# Altium schematic sheet EchoFX.SchDoc
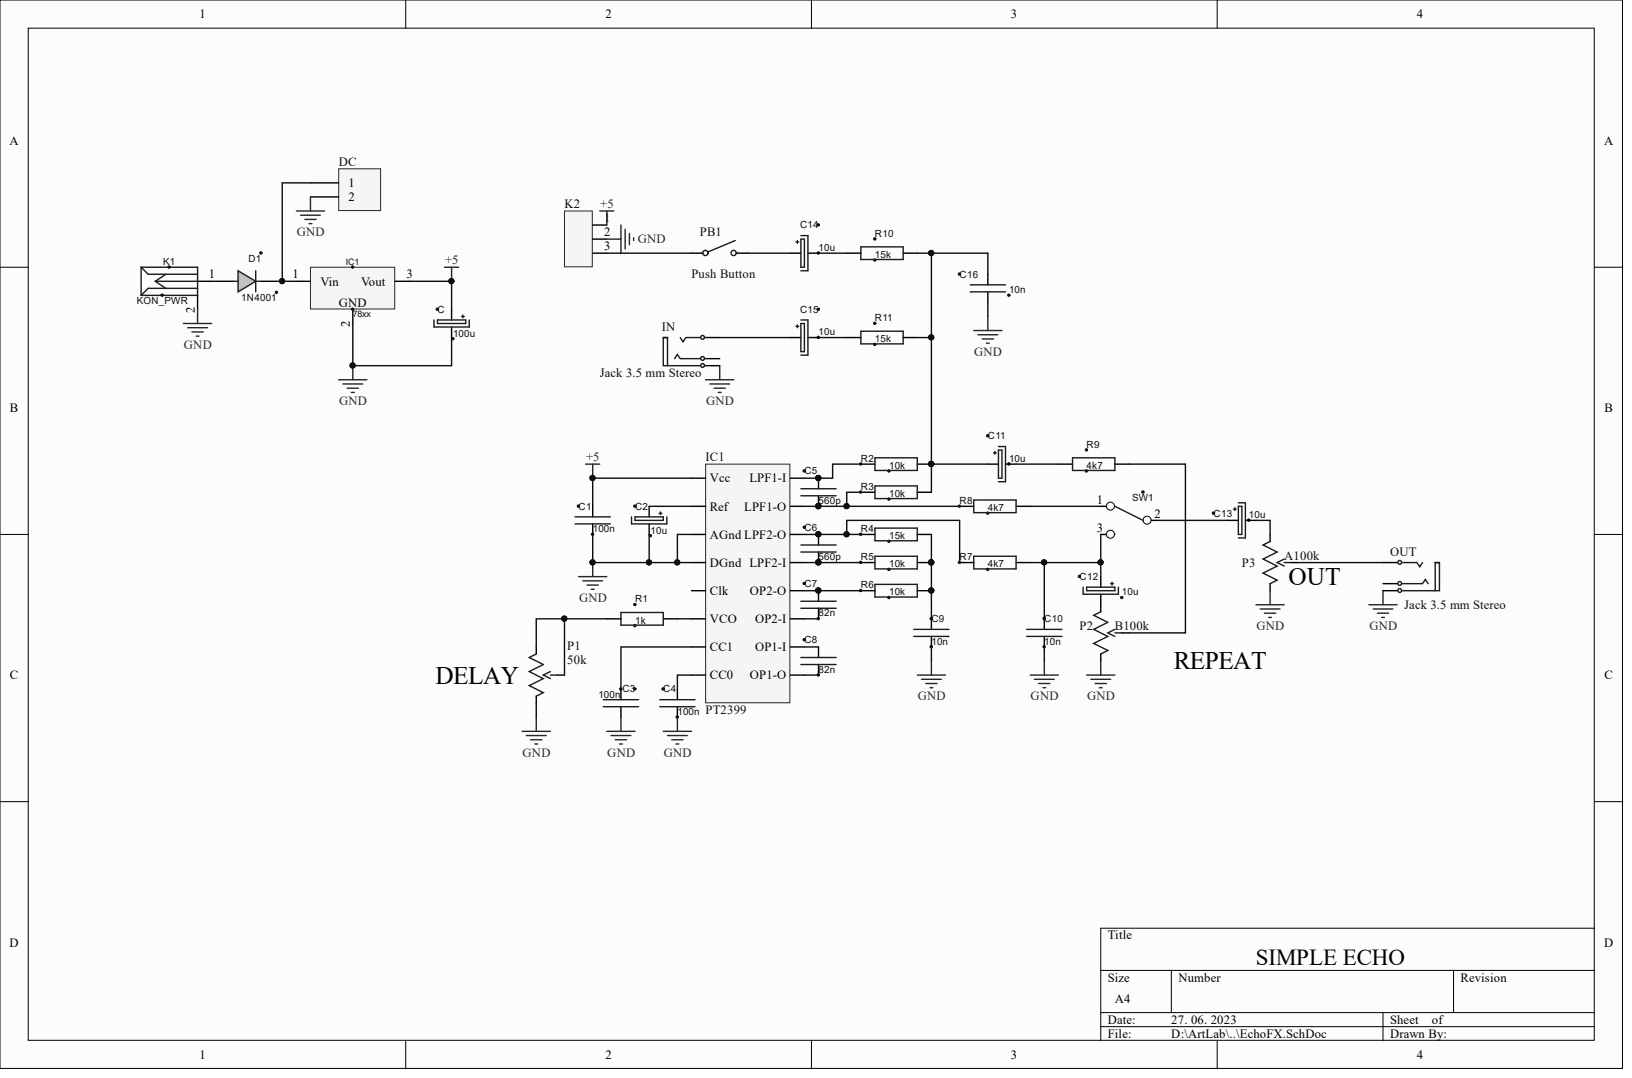
[diagram p0: EchoFX.SchDoc]

## Parts
C  100u  [C AlElko R2.54 FI5]
C1  100n  [C Square R5.08 (200mil)]
C2  10u  [C AlElko R2.54 FI5]
C3  100n  [C Square R5.08 (200mil)]
C4  100n  [C Square R5.08 (200mil)]
C5  560p  [C Square R5.08 (200mil)]
C6  560p  [C Square R5.08 (200mil)]
C7  82n  [C Square R5.08 (200mil)]
C8  82n  [C Square R5.08 (200mil)]
C9  10n  [C Square R5.08 (200mil)]
C10  10n  [C Square R5.08 (200mil)]
C11  10u  [C AlElko R2.54 FI5]
C12  10u  [C AlElko R2.54 FI5]
C13  10u  [C AlElko R2.54 FI5]
C14  10u  [C AlElko R2.54 FI5]
C15  10u  [C AlElko R2.54 FI5]
C16  10n  [C Square R5.08 (200mil)]
D1  1N4001  [DO 41 (400MILS) larger pads]
DC  Header 2H  [HDR1X2H]  (value not drawn)
IC1  78xx  [TO-92]
IC1  PT2399  [DIP16]
IN  Jack 3.5 mm Stereo  [FC68131]
K1  KON_PWR  [_KON_PWR_KON_PWR(Primary)]
K2  H1x3  [H1x3]  (value not drawn)
OUT  Jack 3.5 mm Stereo  [FC68131]
P1  50k  [PDB181- P]
P2  B100k  [PDB181- P]
P3  A100k  [PDB181- P]
PB1  Push Button  [D6R SPST]
R1  1k  [R300]
R2  10k  [R300]
R3  10k  [R300]
R4  15k  [R300]
R5  10k  [R300]
R6  10k  [R300]
R7  4k7  [R300]
R8  4k7  [R300]
R9  4k7  [R300]
R10  15k  [R300]
R11  15k  [R300]
SW1  OS102011MA1QN1  [OS102011-3POLNI SLIDE]  (value not drawn)

## Nets
+5: C.1 C1.2 IC1.1 IC1.3 K2.1
GND: C.2 C1.1 C2.2 C3.2 C4.1 C9.1 C10.1 C16.1 DC.2 IC1.2 IC1.3 IC1.4(DGnd) IN.1 K1.2 K2.2 OUT.1 P1.1 P2.1 P3.1
NetC2_1: C2.1 IC1.2
NetC3_1: C3.1 IC1.7(CC1)
NetC4_2: C4.2 IC1.8(CC0)
NetC5_1: C5.1 IC1.15(LPF1-O) R3.2 R8.1
NetC5_2: C5.2 IC1.16(LPF1-I) R2.2
NetC6_1: C6.1 IC1.13(LPF2-I) R5.1
NetC6_2: C6.2 IC1.14(LPF2-O) R4.2 R7.1
NetC7_1: C7.1 IC1.11(OP2-I)
NetC7_2: C7.2 IC1.12(OP2-O) R6.1
NetC8_1: C8.1 IC1.9(OP1-O)
NetC8_2: C8.2 IC1.10(OP1-I)
NetC9_2: C9.2 R4.1 R5.2 R6.2
NetC10_2: C10.2 C12.1 R7.2 SW1.3
NetC11_1: C11.1 C16.2 R2.1 R3.1 R10.2 R11.2
NetC11_2: C11.2 R9.2
NetC12_2: C12.2 P2.3
NetC13_1: C13.1 SW1.2
NetC13_2: C13.2 P3.3
NetC14_1: C14.1 PB1.2
NetC14_2: C14.2 R10.1
NetC15_1: C15.1 IN.5
NetC15_2: C15.2 R11.1
NetD1_A: D1.A K1.1
NetD1_K: D1.K DC.1 IC1.1
NetIC1_6: IC1.6(VCO) R1.2
NetK2_3: K2.3 PB1.1
NetOUT_5: OUT.5 P3.2
NetP1_2: P1.2 P1.3 R1.1
NetP2_2: P2.2 R9.1
NetR8_2: R8.2 SW1.1

Not connected: IC1 5(Clk); IN 2; OUT 2

## Library data of the parts
C C2 C11-C15 = C+: Capacitor Polarized; Component Kind: Standard; Library Name: General.IntLib
  C: Value: 100u
  C2 C11-C15: Value: 10u
C1 C3-C10 C16 = C: Capacitor; Component Kind: Standard; Library Name: General.IntLib
  C1 C3 C4: Value: 100n
  C5 C6: Value: 560p
  C7 C8: Value: 82n
  C9 C10 C16: Value: 10n
DC = Header 2H: Header, 2-Pin, Right Angle; Component Kind: Standard; LatestRevisionDate: 17-Jul-2002; LatestRevisionNote: Re-released for DXP Platform.; Library Name: Miscellaneous Connectors.IntLib; Signal Integrity: Connector; Publisher: Altium Limited
IC1 = 78xx: 3-point linear voltage regulator series 78xx; Component Kind: Standard; Library Name: ICgeneral.IntLib
IC1 = PT2399 Echo-Delay: Component Kind: Standard; Description: PT2399 Echo-Delay; Library Name: Eurorack.IntLib
IN OUT = Stereo Jack 3.5 mm 3-pin: Jack 3.5 mm PCB mount 3-pin Stereo; Component Kind: Standard; ComponentLink1Description: Datasheet; ComponentLink1URL: https://si.farnell.com/cliff-electronic-components/fc68131/stereo-jack-3-5mm-3pos-pcb/dp/2518188; ComponentLink1URL: http://si.farnell.com/webapp/wcs/stores/servlet/ProductDisplay?catalogId=10001&langId=386&urlRequestType=Base&partNumber=1267400&storeId=10175; Library Name: Eurorack.IntLib
K2 = H1x3: Header 1x3 (male); Component Kind: Standard; Library Name: Kon_Tipk.IntLib
P1-P3 = Potentiometer Rotary: Component Kind: Standard; ComponentLink1description: datasheet; ComponentLink1URL: https://www.bourns.com/docs/product-datasheets/PDB18.pdf; ComponentLink1URL: http://si.farnell.com/webapp/wcs/stores/servlet/Search?catalogId=15001&langId=386&storeId=10175&categoryId=700000005476&sort=P_PRICE&pageSize=25&beginIndex=1&showResults=true&pf=110131914,113164069; Description: Potentiometer Rotary; Library Name: Eurorack.IntLib
  P1: Value: 50k
  P2: Value: B100k
  P3: Value: A100k
PB1 = PB-SPST: Push Button Switch; Component Kind: Standard; Library Name: ArtLab.IntLib
R1-R11 = R: Component Kind: Standard; Description: R; Library Name: General.IntLib
  R1: Value: 1k
  R2 R3 R5 R6: Value: 10k
  R4 R10 R11: Value: 15k
  R7-R9: Value: 4k7
D1 = 1N4001: Component Kind: Standard; Description: 1N4001; Library Name: SemiCond.IntLib
K1 = KON_PWR: Component Kind: Standard; Description: KON_PWR; Library Name: Kon_Tipk.IntLib
SW1 = OS102011MA1QN1: Component Kind: Standard; Description: OS102011MA1QN1; Library Name: Kon_Tipk.IntLib
